# Altium schematic sheet lc4_divider_one_iter.SchDoc
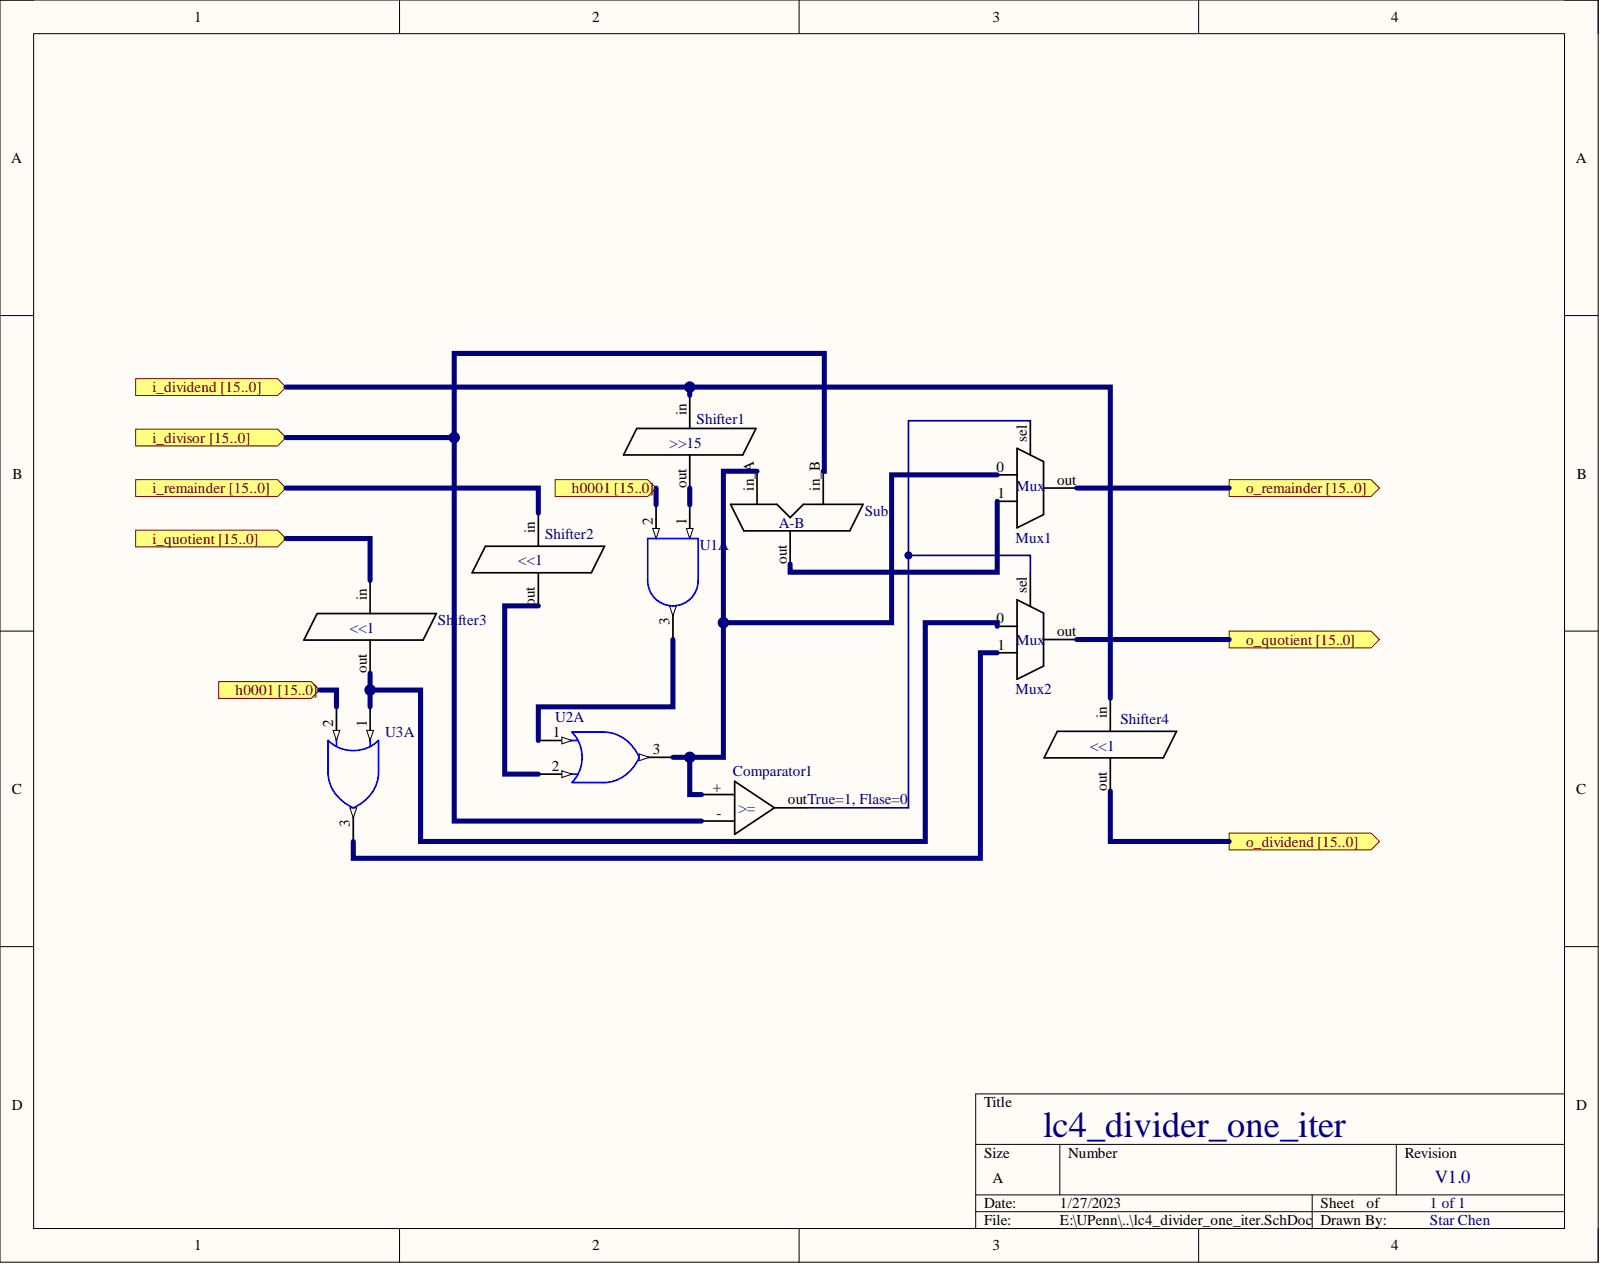
[diagram p0: lc4_divider_one_iter.SchDoc]

## Parts
Comparator1  >=
Mux1  Mux
Mux2  Mux
Shifter1  >>15
Shifter2  <<1
Shifter3  <<1
Shifter4  <<1
Sub1  A-B
U1  SN74HC08PWLE  [PW014]  (value not drawn)  (part A)
U2  SN74HC32N  [N014]  (value not drawn)  (part A)
U3  SN74HC32N  [N014]  (value not drawn)  (part A)

## Ports
h0001 0, h0001 1, h0001 2, h0001 3, h0001 4, h0001 5, h0001 6, h0001 7, h0001 8, h0001 9, h0001 10, h0001 11, h0001 12, h0001 13, h0001 14, h0001 15, h0001 [15..0], i_dividend 0, i_dividend 1, i_dividend 2, i_dividend 3, i_dividend 4, i_dividend 5, i_dividend 6, i_dividend 7, i_dividend 8, i_dividend 9, i_dividend 10, i_dividend 11, i_dividend 12, i_dividend 13, i_dividend 14, i_dividend 15, i_dividend [15..0], i_divisor 0, i_divisor 1, i_divisor 2, i_divisor 3, i_divisor 4, i_divisor 5, i_divisor 6, i_divisor 7, i_divisor 8, i_divisor 9, i_divisor 10, i_divisor 11, i_divisor 12, i_divisor 13, i_divisor 14, i_divisor 15, i_divisor [15..0], i_quotient 0, i_quotient 1, i_quotient 2, i_quotient 3, i_quotient 4, i_quotient 5, i_quotient 6, i_quotient 7, i_quotient 8, i_quotient 9, i_quotient 10, i_quotient 11, i_quotient 12, i_quotient 13, i_quotient 14, i_quotient 15, i_quotient [15..0], i_remainder 0, i_remainder 1, i_remainder 2, i_remainder 3, i_remainder 4, i_remainder 5, i_remainder 6, i_remainder 7, i_remainder 8, i_remainder 9, i_remainder 10, i_remainder 11, i_remainder 12, i_remainder 13, i_remainder 14, i_remainder 15, i_remainder [15..0], o_dividend 0, o_dividend 1, o_dividend 2, o_dividend 3, o_dividend 4, o_dividend 5, o_dividend 6, o_dividend 7, o_dividend 8, o_dividend 9, o_dividend 10, o_dividend 11, o_dividend 12, o_dividend 13, o_dividend 14, o_dividend 15, o_dividend [15..0], o_quotient 0, o_quotient 1, o_quotient 2, o_quotient 3, o_quotient 4, o_quotient 5, o_quotient 6, o_quotient 7, o_quotient 8, o_quotient 9, o_quotient 10, o_quotient 11, o_quotient 12, o_quotient 13, o_quotient 14, o_quotient 15, o_quotient [15..0], o_remainder 0, o_remainder 1, o_remainder 2, o_remainder 3, o_remainder 4, o_remainder 5, o_remainder 6, o_remainder 7, o_remainder 8, o_remainder 9, o_remainder 10, o_remainder 11, o_remainder 12, o_remainder 13, o_remainder 14, o_remainder 15, o_remainder [15..0]

## Nets
GND: U1.7 U2.7 U3.7
VCC: U1.14 U2.14 U3.14
NetComparator1_out: Comparator1.out Mux1.sel Mux2.sel
N00001_0: ; port o_dividend [15..0]
N00001_1: ; port o_dividend [15..0]
N00001_2: ; port o_dividend [15..0]
N00001_3: ; port o_dividend [15..0]
N00001_4: ; port o_dividend [15..0]
N00001_5: ; port o_dividend [15..0]
N00001_6: ; port o_dividend [15..0]
N00001_7: ; port o_dividend [15..0]
N00001_8: ; port o_dividend [15..0]
N00001_9: ; port o_dividend [15..0]
N00001_10: ; port o_dividend [15..0]
N00001_11: ; port o_dividend [15..0]
N00001_12: ; port o_dividend [15..0]
N00001_13: ; port o_dividend [15..0]
N00001_14: ; port o_dividend [15..0]
N00001_15: ; port o_dividend [15..0]
N00002_0: ; port i_dividend [15..0]
N00002_1: ; port i_dividend [15..0]
N00002_2: ; port i_dividend [15..0]
N00002_3: ; port i_dividend [15..0]
N00002_4: ; port i_dividend [15..0]
N00002_5: ; port i_dividend [15..0]
N00002_6: ; port i_dividend [15..0]
N00002_7: ; port i_dividend [15..0]
N00002_8: ; port i_dividend [15..0]
N00002_9: ; port i_dividend [15..0]
N00002_10: ; port i_dividend [15..0]
N00002_11: ; port i_dividend [15..0]
N00002_12: ; port i_dividend [15..0]
N00002_13: ; port i_dividend [15..0]
N00002_14: ; port i_dividend [15..0]
N00002_15: ; port i_dividend [15..0]
N00003_0: ; port i_quotient [15..0]
N00003_1: ; port i_quotient [15..0]
N00003_2: ; port i_quotient [15..0]
N00003_3: ; port i_quotient [15..0]
N00003_4: ; port i_quotient [15..0]
N00003_5: ; port i_quotient [15..0]
N00003_6: ; port i_quotient [15..0]
N00003_7: ; port i_quotient [15..0]
N00003_8: ; port i_quotient [15..0]
N00003_9: ; port i_quotient [15..0]
N00003_10: ; port i_quotient [15..0]
N00003_11: ; port i_quotient [15..0]
N00003_12: ; port i_quotient [15..0]
N00003_13: ; port i_quotient [15..0]
N00003_14: ; port i_quotient [15..0]
N00003_15: ; port i_quotient [15..0]
N00004_0: ; port o_remainder [15..0]
N00004_1: ; port o_remainder [15..0]
N00004_2: ; port o_remainder [15..0]
N00004_3: ; port o_remainder [15..0]
N00004_4: ; port o_remainder [15..0]
N00004_5: ; port o_remainder [15..0]
N00004_6: ; port o_remainder [15..0]
N00004_7: ; port o_remainder [15..0]
N00004_8: ; port o_remainder [15..0]
N00004_9: ; port o_remainder [15..0]
N00004_10: ; port o_remainder [15..0]
N00004_11: ; port o_remainder [15..0]
N00004_12: ; port o_remainder [15..0]
N00004_13: ; port o_remainder [15..0]
N00004_14: ; port o_remainder [15..0]
N00004_15: ; port o_remainder [15..0]
N00005_0: ; port i_divisor [15..0]
N00005_1: ; port i_divisor [15..0]
N00005_2: ; port i_divisor [15..0]
N00005_3: ; port i_divisor [15..0]
N00005_4: ; port i_divisor [15..0]
N00005_5: ; port i_divisor [15..0]
N00005_6: ; port i_divisor [15..0]
N00005_7: ; port i_divisor [15..0]
N00005_8: ; port i_divisor [15..0]
N00005_9: ; port i_divisor [15..0]
N00005_10: ; port i_divisor [15..0]
N00005_11: ; port i_divisor [15..0]
N00005_12: ; port i_divisor [15..0]
N00005_13: ; port i_divisor [15..0]
N00005_14: ; port i_divisor [15..0]
N00005_15: ; port i_divisor [15..0]
N00006_0: ; port o_quotient [15..0]
N00006_1: ; port o_quotient [15..0]
N00006_2: ; port o_quotient [15..0]
N00006_3: ; port o_quotient [15..0]
N00006_4: ; port o_quotient [15..0]
N00006_5: ; port o_quotient [15..0]
N00006_6: ; port o_quotient [15..0]
N00006_7: ; port o_quotient [15..0]
N00006_8: ; port o_quotient [15..0]
N00006_9: ; port o_quotient [15..0]
N00006_10: ; port o_quotient [15..0]
N00006_11: ; port o_quotient [15..0]
N00006_12: ; port o_quotient [15..0]
N00006_13: ; port o_quotient [15..0]
N00006_14: ; port o_quotient [15..0]
N00006_15: ; port o_quotient [15..0]
N00007_0: ; port i_remainder [15..0]
N00007_1: ; port i_remainder [15..0]
N00007_2: ; port i_remainder [15..0]
N00007_3: ; port i_remainder [15..0]
N00007_4: ; port i_remainder [15..0]
N00007_5: ; port i_remainder [15..0]
N00007_6: ; port i_remainder [15..0]
N00007_7: ; port i_remainder [15..0]
N00007_8: ; port i_remainder [15..0]
N00007_9: ; port i_remainder [15..0]
N00007_10: ; port i_remainder [15..0]
N00007_11: ; port i_remainder [15..0]
N00007_12: ; port i_remainder [15..0]
N00007_13: ; port i_remainder [15..0]
N00007_14: ; port i_remainder [15..0]
N00007_15: ; port i_remainder [15..0]
N00008_0: ; port h0001 [15..0]
N00008_1: ; port h0001 [15..0]
N00008_2: ; port h0001 [15..0]
N00008_3: ; port h0001 [15..0]
N00008_4: ; port h0001 [15..0]
N00008_5: ; port h0001 [15..0]
N00008_6: ; port h0001 [15..0]
N00008_7: ; port h0001 [15..0]
N00008_8: ; port h0001 [15..0]
N00008_9: ; port h0001 [15..0]
N00008_10: ; port h0001 [15..0]
N00008_11: ; port h0001 [15..0]
N00008_12: ; port h0001 [15..0]
N00008_13: ; port h0001 [15..0]
N00008_14: ; port h0001 [15..0]
N00008_15: ; port h0001 [15..0]

Not connected: Comparator1 + -; Mux1 0 1 out; Mux2 0 1 out; Shifter1 in out; Shifter2 in out; Shifter3 in out; Shifter4 in out; Sub1 in_A in_B out; U1 1 2 3; U2 1 2 3; U3 1 2 3

## Library data of the parts
Comparator1 = Comparator: Component Kind: Standard; Library Name: Schlib1.SchLib
Shifter1-Shifter4 = Shifter: Component Kind: Standard; Library Name: Schlib1.SchLib
Sub1 = Sub: Component Kind: Standard; Library Name: Schlib1.SchLib
U1 = SN74HC08PWLE: Quadruple 2-Input Positive-AND Gate; Component Kind: Standard; ComponentLink1Description: Manufacturer Link; ComponentLink1URL: http://www.ti.com/; ComponentLink2Description: Datasheet; ComponentLink2URL: http://www-s.ti.com/sc/ds/SN74HC08.pdf; DatasheetVersion: May-1997; LatestRevisionDate: 04-Mar-2005; LatestRevisionNote: Stylized 3D Model Added.; Library Name: TI Logic Gate 2.IntLib; PackageDescription: Shrink Small Outline; 14 Leads; Body Width 4.4 mm; Pitch 0.65 mm; PackageReference: PW014; PackageVersion: Feb-1999; PCB3D: PW014; Published: 8-Jun-2000; Publisher: Altium Limited
U2 U3 = SN74HC32N: Quadruple 2-Input Positive-OR Gate; Component Kind: Standard; ComponentLink1Description: Manufacturer Link; ComponentLink1URL: http://www.ti.com/; ComponentLink2Description: Datasheet; ComponentLink2URL: http://www-s.ti.com/sc/ds/sn74hc32.pdf; DatasheetVersion: May-1997; LatestRevisionDate: 04-Mar-2005; LatestRevisionNote: Stylized 3D Model Added.; Library Name: TI Logic Gate 2.IntLib; PackageDescription: DIP; 14 Leads; Row Spacing 7.62 mm; Pitch 2.54 mm; PackageReference: N014; PackageVersion: Oct-1995; PCB3D: N014; Published: 8-Jun-2000; Publisher: Altium Limited
Mux1 Mux2 = Mux: Component Kind: Standard; Library Name: Schlib1.SchLib
